# Altium schematic sheet 05_Power.SchDoc
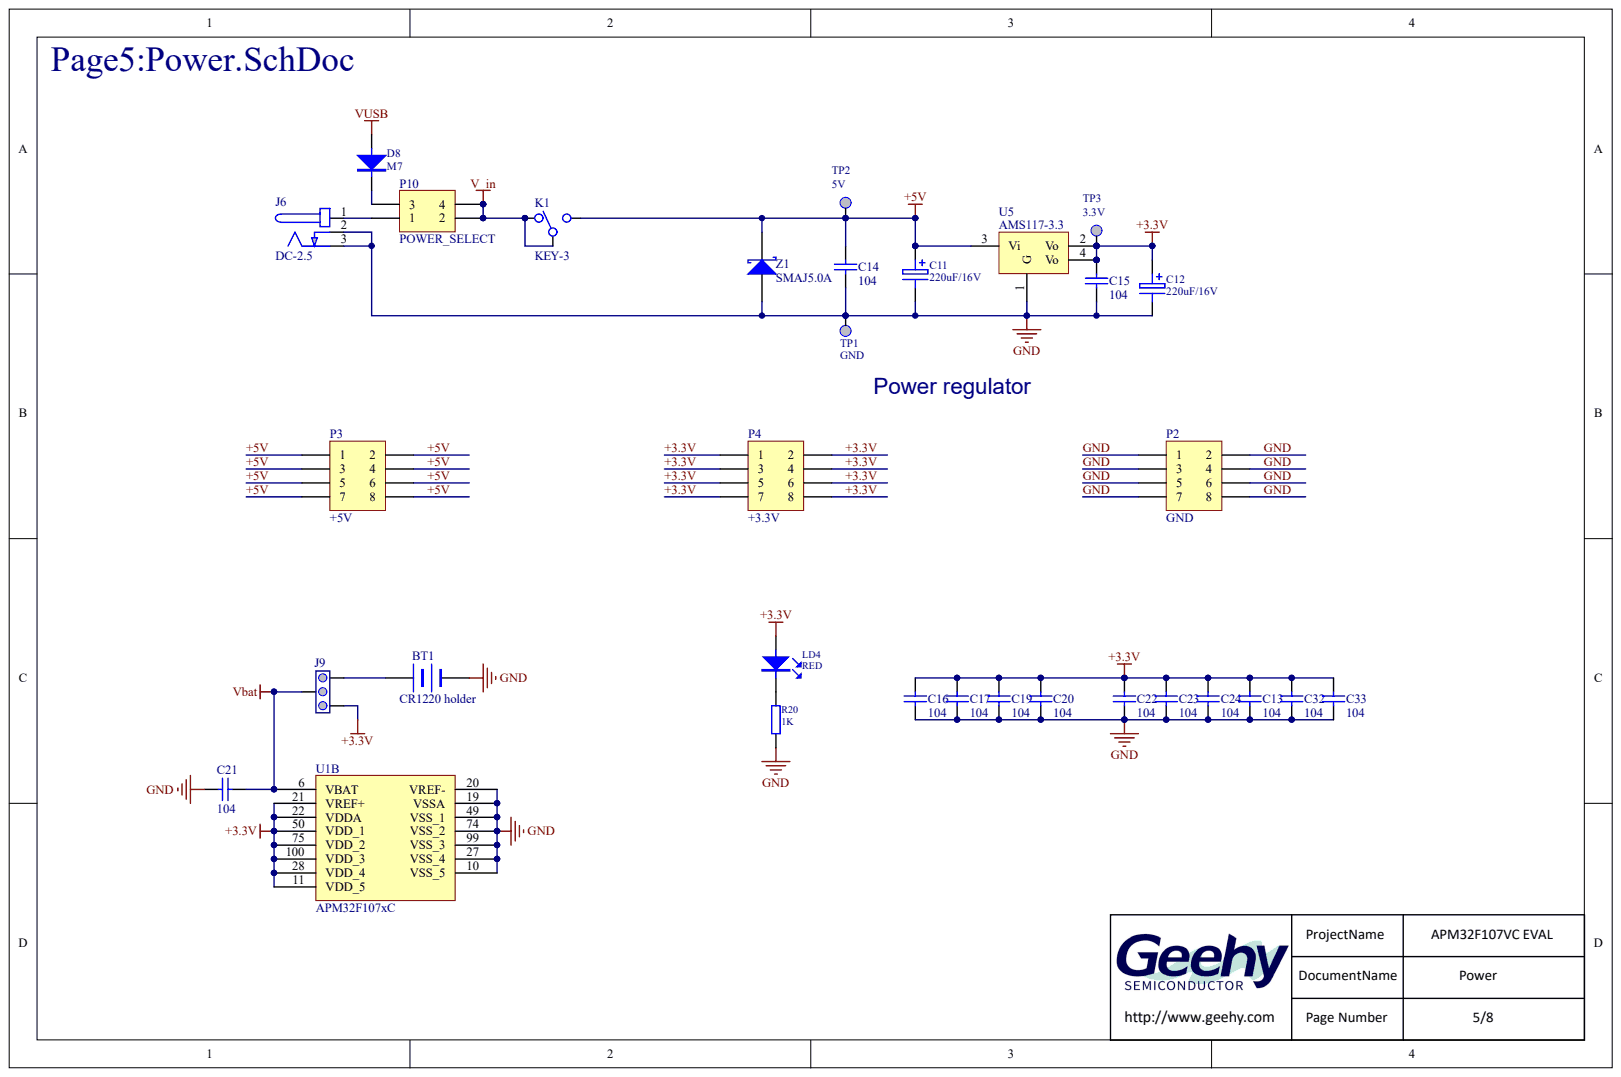
[diagram p0: 05_Power.SchDoc]

## Parts
BT1  CR1220 holder
C11  220uF/16V
C12  220uF/16V
C13  104
C14  104
C15  104
C16  104
C17  104
C19  104
C20  104
C21  104
C22  104
C23  104
C24  104
C32  104
C33  104
D8  M7
J6  DC-2.5
J9  (no value)
K1  KEY-3
LD4  RED
P2  GND
P3  +5V
P4  +3.3V
P10  POWER_SELECT
R20  1K
TP1  GND
TP2  5V
TP3  3.3V
U1  APM32F107xC  (part B)
U5  AMS117-3.3
Z1  SMAJ5.0A

## Nets
V_IN: K1.1 K1.2 P10.2 P10.4
VBAT: C21.1 J9.2 U1.6(VBAT)
VUSB: D8.1
+3.3V: C12.1 C13.1 C15.1 C16.1 C17.1 C19.1 C20.1 C22.1 C23.1 C24.1 C32.1 C33.1 J9.3 LD4.A P4.1 P4.2 P4.3 P4.4 P4.5 P4.6 P4.7 P4.8 TP3.1 U1.11(VDD_5) U1.21(VREF+) U1.22(VDDA) U1.28(VDD_4) U1.50(VDD_1) U1.75(VDD_2) U1.100(VDD_3) U5.2(Vo) U5.4(Vo)
+5V: C11.1 C14.1 K1.3 P3.1 P3.2 P3.3 P3.4 P3.5 P3.6 P3.7 P3.8 TP2.1 U5.3(Vi) Z1.2
GND: BT1.2 C11.2 C12.2 C13.2 C14.2 C15.2 C16.2 C17.2 C19.2 C20.2 C21.2 C22.2 C23.2 C24.2 C32.2 C33.2 J6.2 J6.3 P2.1 P2.2 P2.3 P2.4 P2.5 P2.6 P2.7 P2.8 R20.2 TP1.1 U1.10(VSS_5) U1.19(VSSA) U1.20(VREF-) U1.27(VSS_4) U1.49(VSS_1) U1.74(VSS_2) U1.99(VSS_3) U5.1(G) Z1.1
NetBT1_1: BT1.1 J9.1
NetD8_2: D8.2 P10.3
NetJ6_1: J6.1 P10.1
NetLD4_K: LD4.K R20.1

## Library data of the parts
C13 C15-C17 C19-C24 C32 C33 = (no symbol name): Value: 10nF; Published: 8-Jun-2000; Revision: July-2002: Re-released for DXP Platform.; Publisher: Altium Limited
C14 = (no symbol name): Value: 100pF; Published: 8-Jun-2000; Revision: July-2002: Re-released for DXP Platform.; Publisher: Altium Limited
Z1 = (no symbol name): Code - JEDEC: DO-214-AA; Published: 8-Jun-2000; Revision: July-2002: Re-released for DXP Platform.; Publisher: Altium Limited
BT1 C11 C12 D8 J6 J9 K1 LD4 P2-P4 P10 R20 TP1-TP3 U1 U5 = (no symbol name)
  P2-P4 P10: LatestRevisionDate: 17-Jul-2002; LatestRevisionNote: Re-released for DXP Platform.; Publisher: Altium Limited
